# Altium schematic sheet Main_sch.SchDoc
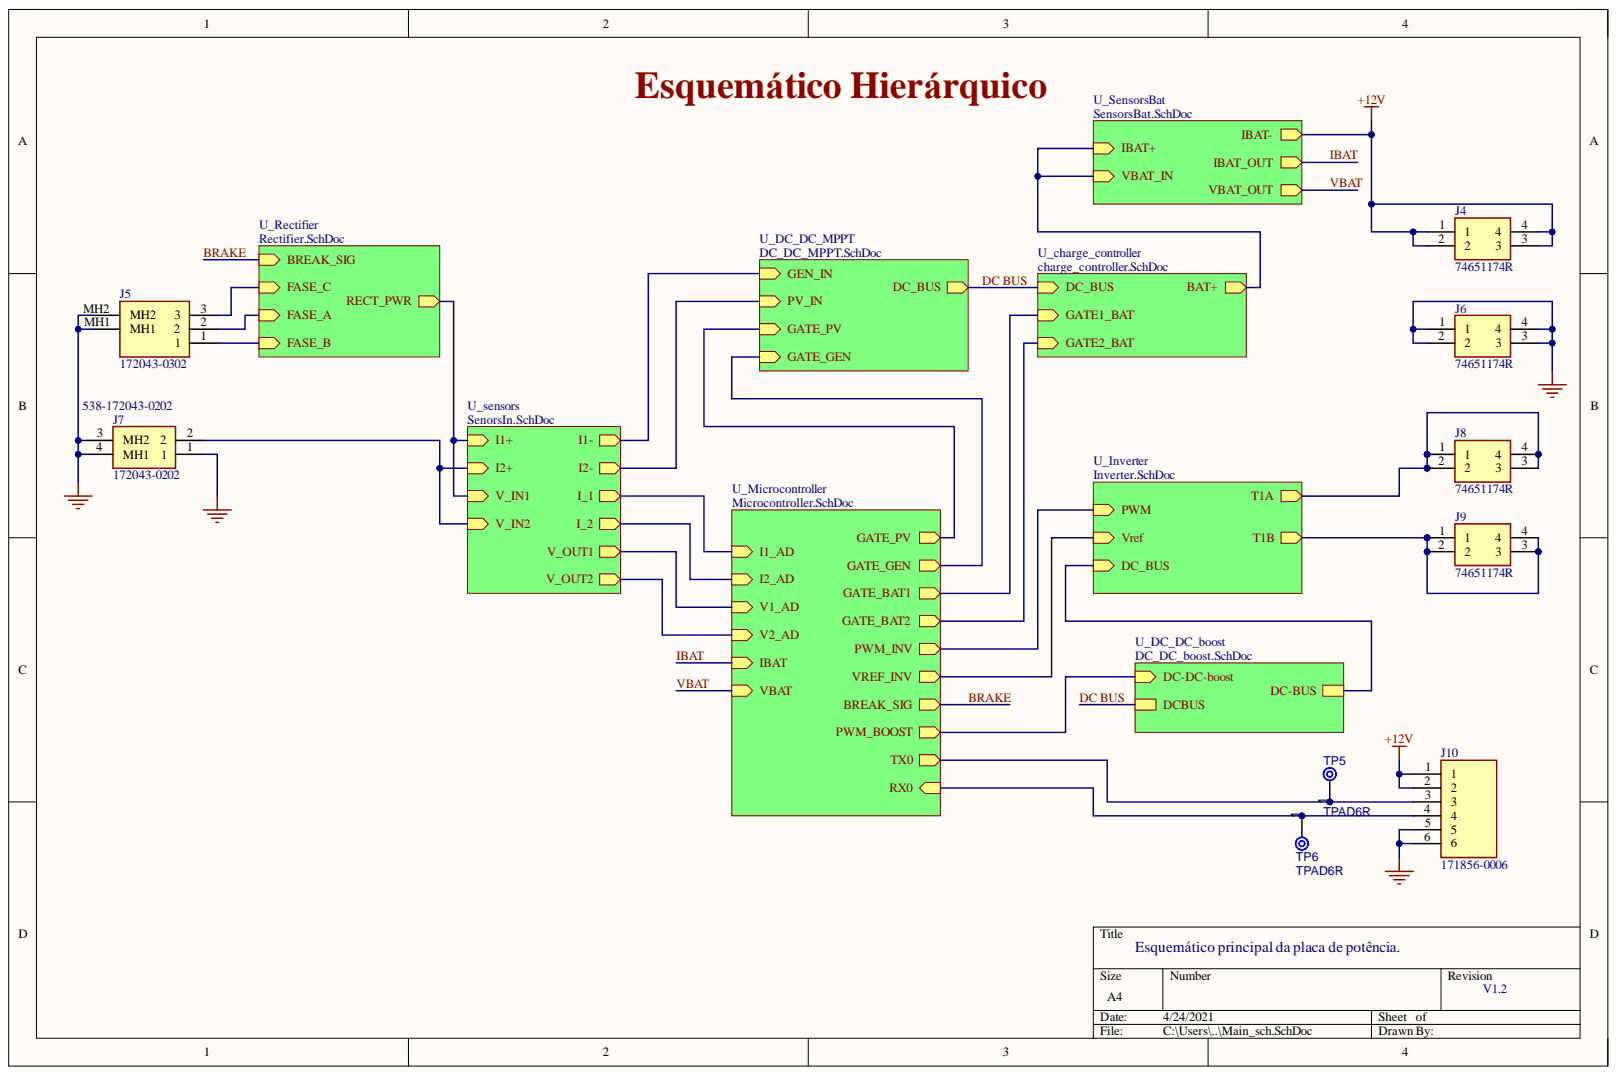
[diagram p0: Main_sch.SchDoc]

## Parts
J4  74651174R  [74651174R]
J5  172043-0302  [1720430302]
J6  74651174R  [74651174R]
J7  172043-0202  Mouser Part Number: 538-172043-0202  [1720430202]
J8  74651174R  [74651174R]
J9  74651174R  [74651174R]
J10  171856-0006  [HDRV6W99P0X254_1X6_1509X635X1100P]
TP5  TPAD6R  [TP06R]  (no BOM)
TP6  TPAD6R  [TP06R]  (no BOM)

## Nets
+12V: J4.1 J4.2 J4.3 J4.4 J10.1 J10.2
GND: J5.MH1 J5.MH2 J6.1 J6.2 J6.3 J6.4 J7.1 J7.3(MH2) J7.4(MH1) J10.5 J10.6
NetJ5_1: J5.1
NetJ5_2: J5.2
NetJ5_3: J5.3
NetJ7_2: J7.2
NetJ8_1: J8.1 J8.2 J8.3 J8.4
NetJ9_1: J9.1 J9.2 J9.3 J9.4
NetJ10_3: J10.3 TP5.1
NetJ10_4: J10.4 TP6.1
BAT+:
BRAKE:
DC BUS:
DC-BUS:
DC-DC-boost:
GATE1_BAT:
GATE2_BAT:
GATE_GEN:
GATE_PV:
GEN_IN:
I1+:
I1_AD:
I2-:
I2_AD:
IBAT:
PWM:
V1_AD:
V2_AD:
VBAT:
Vref:

## Library data of the parts
J4 J6 J8 J9 = 74651174R: SHANK, THR; Manufacturer: Wurth Electronics; Manufacturer_Name: Wurth Elektronik; Current Rating: 50A; Category: Terminals; Component Kind: Standard; ComponentLink1Description: http://katalog.we-online.de/em/datasheet/74651174R.pdf?src-supplier=Digi-Key; ComponentLink1URL: http://katalog.we-online.de/em/datasheet/74651174R.pdf?src-supplier=Digi-Key; ComponentLink2Description: https://katalog.we-online.de/em/datasheet/74651174R.pdf?src-supplier=Digi-Key; ComponentLink2URL: https://katalog.we-online.de/em/datasheet/74651174R.pdf?src-supplier=Digi-Key; Contact Material: Brass; Contact Plating: Tin; Datasheet Link: https://componentsearchengine.com/Datasheets/1/74651174R.pdf; Height: 11mm; Manufacturer Part Number: 74651174R; Manufacturer_Part_Number: 74651174R; Mount: Through Hole; Mouser Part Number: 710-74651174R; Mouser Price/Stock: https://www.mouser.co.uk/ProductDetail/Wurth-Elektronik/74651174R?qs=lBTPRtX1sU9uJ5vjuYZPZA%3D%3D; Number of Pins: 4; Pricing 1: 1=3.26000, 10=3.02000, 50=2.71000, 100=2.45000, 1000=2.06000, 10000=2.06000 (USD); RoHS: Yes; RoHS Compliant: Yes; Stock 1: 997; Supplier 1: Mouser; Supplier Part Number 1: 710-74651174R; Termination: Solder
J5 = 172043-0302: Conn Power HDR 3 POS 7.49mm Solder RA Thru-Hole 3 Terminal 1 Port Super Sabre™ Frame; Manufacturer: Molex; Current Rating: 30A; Glow Wire Compliant: Compliant; Number of Circuits: 3; Pitch: 0.295inch; Voltage Rating: 600V; Category: Headers and Wire Housings; Color: Black; Component Kind: Standard; ComponentLink1Description: http://www.molex.com/pdm_docs/sd/1720430202_sd.pdf?src-supplier=Avnet; ComponentLink1URL: http://www.molex.com/pdm_docs/sd/1720430202_sd.pdf?src-supplier=Avnet; ComponentLink2Description: http://www.molex.com/pdm_docs/sd/1720430302_sd.pdf?src-supplier=Digi-Key; ComponentLink2URL: http://www.molex.com/pdm_docs/sd/1720430302_sd.pdf?src-supplier=Digi-Key; ComponentLink3Description: https://www.molex.com/pdm_docs/sd/1720430302_sd.pdf?src-supplier=Digi-Key; ComponentLink3URL: https://www.molex.com/pdm_docs/sd/1720430302_sd.pdf?src-supplier=Digi-Key; ComponentLink4Description: https://www.mouser.com/ds/2/276/1720430202_PCB_HEADERS-704499.pdf?src-supplier=Mouser; ComponentLink4URL: https://www.mouser.com/ds/2/276/1720430202_PCB_HEADERS-704499.pdf?src-supplier=Mouser; ComponentLink5Description: http://www.literature.molex.com/SQLImages/kelmscott/Molex/PDF_Images/987650-1349.PDF?src-supplier=Digi-Key; ComponentLink5URL: http://www.literature.molex.com/SQLImages/kelmscott/Molex/PDF_Images/987650-1349.PDF?src-supplier=Digi-Key; ComponentLink6Description: http://www.molex.com/pdm_docs/sd/1720430202_sd.pdf?src-supplier=Digi-Key; ComponentLink6URL: http://www.molex.com/pdm_docs/sd/1720430202_sd.pdf?src-supplier=Digi-Key; Contact Material: Copper; Contact Plating: Silver; Datasheet Link: https://www.molex.com/pdm_docs/sd/1720430401_sd.pdf; Fastening Type: Ramp; Halogen Free: Low Halogen; Height: 11.53mm; Insulation Material: Polymer; Library Name: pi2.SchLib; Manufacturer Part Number: 1720430302; Manufacturer_Name: Molex; Manufacturer_Part_Number: 172043-0302; Max Operating Temperature: 125°C; Min Operating Temperature: -40°C; Mount: Through Hole; Number of Pins: 3; Number of Positions: 3; Number of Rows: 1; Orientation: Right Angle; Packaging: Tray; Pricing 1: 1=4.13000, 10=3.64000, 50=3.64000, 100=2.90000, 1000=2.39000, 10000=2.23000 (USD); RoHS: Yes; RoHS Compliant: Yes; Stock 1: 770; Supplier 1: Mouser; Supplier Part Number 1: 538-172043-0302; Termination: Solder
J7 = 172043-0202: CONN HDR 2POS 7.50MM R/A SLDR; Manufacturer: Molex; Current Rating: 30A; Number of Circuits: 2; Pitch: 0.295inch; Voltage Rating: 600V; Category: Cables and Wire; Color: Black; Component Kind: Standard; ComponentLink1Description: https://www.mouser.com/ds/2/276/1720430202_PCB_HEADERS-704499.pdf?src-supplier=Mouser; ComponentLink1URL: https://www.mouser.com/ds/2/276/1720430202_PCB_HEADERS-704499.pdf?src-supplier=Mouser; ComponentLink2Description: http://www.molex.com/pdm_docs/sd/1720430202_sd.pdf?src-supplier=Avnet; ComponentLink2URL: http://www.molex.com/pdm_docs/sd/1720430202_sd.pdf?src-supplier=Avnet; ComponentLink3Description: http://www.literature.molex.com/SQLImages/kelmscott/Molex/PDF_Images/987650-1349.PDF?src-supplier=Digi-Key; ComponentLink3URL: http://www.literature.molex.com/SQLImages/kelmscott/Molex/PDF_Images/987650-1349.PDF?src-supplier=Digi-Key; ComponentLink4Description: http://www.molex.com/pdm_docs/sd/1720430202_sd.pdf?src-supplier=Digi-Key; ComponentLink4URL: http://www.molex.com/pdm_docs/sd/1720430202_sd.pdf?src-supplier=Digi-Key; Contact Material: Copper; Contact Plating: Silver; Datasheet Link: https://www.molex.com/pdm_docs/sd/1720430202_sd.pdf; Fastening Type: Ramp; Height: 11.68mm; Insulation Material: Polymer; Library Name: pi2.SchLib; Manufacturer Part Number: 1720430202; Manufacturer_Name: Molex; Manufacturer_Part_Number: 172043-0202; Max Operating Temperature: 125°C; Min Operating Temperature: -40°C; Mount: Through Hole; Mouser Price/Stock: https://www.mouser.co.uk/ProductDetail/Molex/172043-0202?qs=AUG1J5sL7zJwcA2Oseq0RA%3D%3D; Number of Pins: 2; Number of Positions: 2; Number of Rows: 1; Orientation: Right Angle; Packaging: Tray; Pricing 1: 1=2.21000, 10=1.89000, 50=1.89000, 100=1.56000, 1000=1.22000, 10000=1.08000 (USD); RoHS: Yes; RoHS Compliant: Yes; Stock 1: 939; Supplier 1: Mouser; Supplier Part Number 1: 538-172043-0202; Termination: Solder
J10 = 171856-0006: Conn Wire to Board HDR 6 POS 2.54mm Solder ST Thru-Hole KK® Bag; Manufacturer: Molex; Connector Type: Male Pin; Contact Post Length: 2.34mm; Current Rating: 4A; Gender: Male; Glow Wire Compliant: Compliant; Number of Circuits: 6; Voltage Rating: 250V; Category: Headers and Wire Housings; Color: Black; Component Kind: Standard; ComponentLink1Description: https://datasheet.ciiva.com/24135/1718560006-pcb-headers-295618-24135976.pdf?src-supplier=Mouser; ComponentLink1URL: https://datasheet.ciiva.com/24135/1718560006-pcb-headers-295618-24135976.pdf?src-supplier=Mouser; ComponentLink2Description: https://datasheet.ciiva.com/30069/162461718560006-pcb-headers-30069863.pdf?src-supplier=Onlinecomponents.com; ComponentLink2URL: https://datasheet.ciiva.com/30069/162461718560006-pcb-headers-30069863.pdf?src-supplier=Onlinecomponents.com; ComponentLink3Description: https://datasheet.ciiva.com/28500/1769843-28500365.pdf?src-supplier=Element14; ComponentLink3URL: https://datasheet.ciiva.com/28500/1769843-28500365.pdf?src-supplier=Element14; ComponentLink4Description: https://datasheet.ciiva.com/30492/0900766b8129e59b-30492739.pdf?src-supplier=RSComponents; ComponentLink4URL: https://datasheet.ciiva.com/30492/0900766b8129e59b-30492739.pdf?src-supplier=RSComponents; ComponentLink5Description: https://datasheet.ciiva.com/29948/1718560002-sd-29948527.pdf?src-supplier=Avnet; ComponentLink5URL: https://datasheet.ciiva.com/29948/1718560002-sd-29948527.pdf?src-supplier=Avnet; ComponentLink6Description: https://datasheet.ciiva.com/30514/70314281-30514598.pdf?src-supplier=Allied+Electronics; ComponentLink6URL: https://datasheet.ciiva.com/30514/70314281-30514598.pdf?src-supplier=Allied+Electronics; Contact Gender: Male; Contact Material: Brass,Tin; Contact Mating Length: 0.293inch; Contact Plating: Tin,Matte; Datasheet Link: https://www.molex.com/pdm_docs/sd/1718560006_sd.pdf; Depth: 6.35mm; Fastening Type: Friction; Flammability Rating: UL94 V-2; Halogen Free: Low Halogen; Height: 11mm; Housing Color: Black; Housing Material: Nylon; Length: 15.09mm; Manufacturer Part Number: 171856-0006; Manufacturer_Name: Molex; Manufacturer_Part_Number: 171856-0006; Max Operating Temperature: 105°C; Min Operating Temperature: -40°C; Mount: Through Hole; Mouser Part Number: 538-171856-0006; Mouser Price/Stock: https://www.mouser.co.uk/ProductDetail/Molex/171856-0006?qs=s7UCm7gO1bZ%2FF4kPA9T7bA%3D%3D; Number of Contacts: 6; Number of Positions: 6; Number of Rows: 1; Orientation: Vertical; Package Quantity: 2000; Packaging: Bulk; Pitch: 2.54mm; Pricing 1: 1=0.52000, 10=0.44100, 50=0.44100, 100=0.34400, 1000=0.27000, 10000=0.22000 (USD); Radiation Hardening: No; REACH SVHC: Unknown; RoHS: Yes; RoHS Compliant: Yes; Stock 1: 7773; Supplier 1: Mouser; Supplier Part Number 1: 538-171856-0006; Termination: Solder; Weight: 0.023916oz
TP5 TP6 = CMP-00028-00002-2: 0.6mm round; Library Name: PI2; Pins: 1
